# Altium schematic sheet power.SchDoc(power)
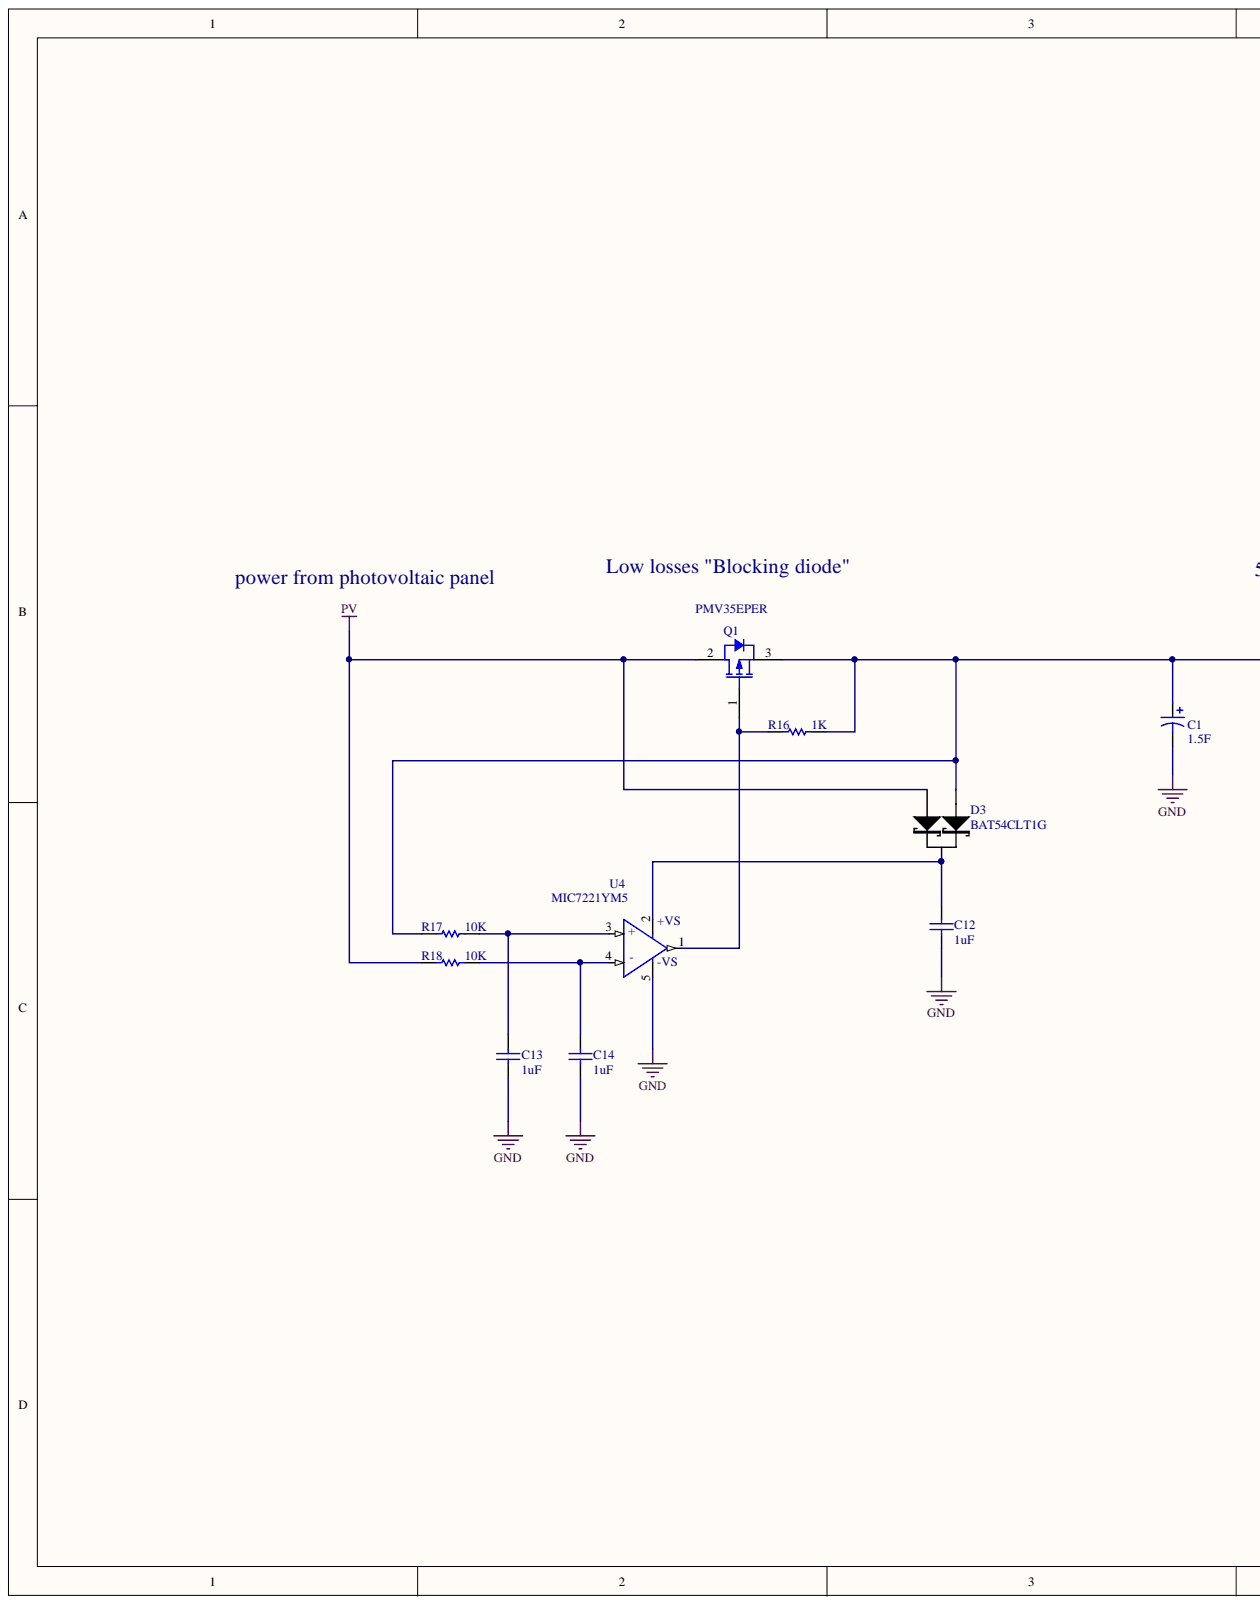
[diagram p0: power.SchDoc, left]
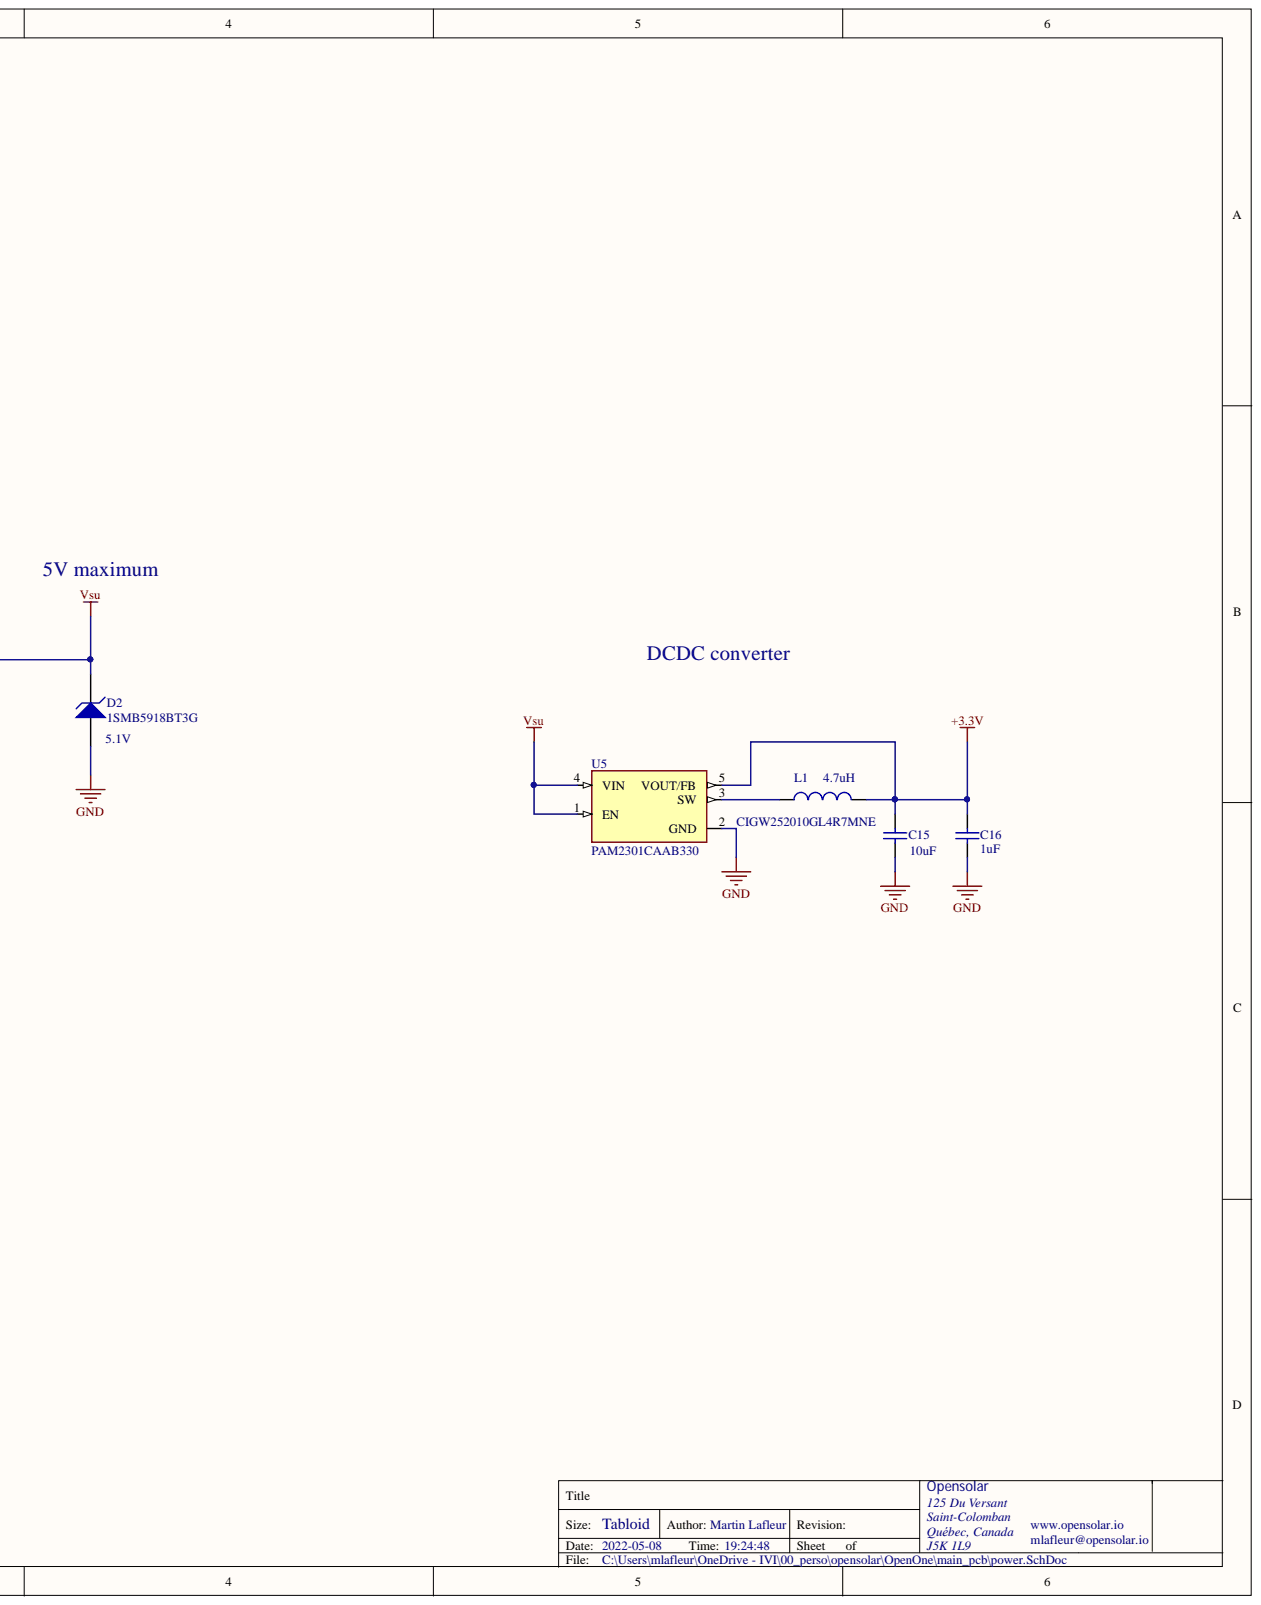
[diagram p1: power.SchDoc, right]

## Parts
C1  value 1.5F  [SCMR22H155PSBB0]  (hidden comment: Cap Pol1)
C12  1uF  [6-0805_M]
C13  1uF  [6-0805_M]
C14  1uF  [6-0805_M]
C15  10uF  [6-0805_M]
C16  1uF  [6-0805_M]
D2  1SMB5918BT3G  value 5.1V  [SMB]
D3  BAT54CLT1G  [SOT23-DUODIODE]
L1  CIGW252010GL4R7MNE  value 4.7uH  [INDUCTOR_2520 - duplicate1]
Q1  PMV35EPER  [PMV35EPER]
R16  1K  [6-0805_N]
R17  10K  [6-0805_N]
R18  10K  [6-0805_N]
U4  MIC7221YM5  [MIC7221YM5]
U5  PAM2301CAAB330  [SOT95P280X100-5N]

## Nets
+3.3V: C15.2 C16.2 L1.2 U5.5(VOUT/FB)
GND: C1.2 C12.1 C13.1 C14.1 C15.1 C16.1 D2.1 U4.5 U5.2(GND)
PV: D3.2 Q1.2 R18.1
Vsu: C1.1 D2.2 D3.1 Q1.3 R16.2 R17.1 U5.1(EN) U5.4(VIN)
NetC12_2: C12.2 D3.3 U4.2
NetC13_2: C13.2 R17.2 U4.3(+)
NetC14_2: C14.2 R18.2 U4.4
NetL1_1: L1.1 U5.3(SW)
NetQ1_1: Q1.1 R16.1 U4.1

## Library data of the parts
C1 = Cap Pol1: Polarized Capacitor (Radial); Published: 8-Jun-2000; PackageReference: RB7.6-15; PackageDescription: Polarized Capacitor (Radial); 2 Leads; Library Name: Miscellaneous Devices.IntLib; LatestRevisionNote: Re-released for DXP Platform.; LatestRevisionDate: 17-Jul-2002; Component Kind: Standard; Publisher: Altium Limited
C12-C14 = Cap: Capacitor; Value: 1uF; Published: 8-Jun-2000; PackageReference: RAD-0.3; PackageDescription: Radial Cap, Thru-Hole; 2 Leads; 0.3 in Pin Spacing; Library Name: Miscellaneous Devices.IntLib; LatestRevisionNote: Re-released for DXP Platform.; LatestRevisionDate: 17-Jul-2002; jlc: C28323; Component Kind: Standard; Publisher: Altium Limited
C15 = Cap: Value: 10uF; Published: 8-Jun-2000; PackageReference: RAD-0.3; PackageDescription: Radial Cap, Thru-Hole; 2 Leads; 0.3 in Pin Spacing; Library Name: Miscellaneous Devices.IntLib; LatestRevisionNote: Re-released for DXP Platform.; LatestRevisionDate: 17-Jul-2002; jlc: C15850; Component Kind: Standard; Publisher: Altium Limited
C16 = Cap: Capacitor; Value: 100nF; Published: 8-Jun-2000; PackageReference: RAD-0.3; PackageDescription: Radial Cap, Thru-Hole; 2 Leads; 0.3 in Pin Spacing; Library Name: Miscellaneous Devices.IntLib; LatestRevisionNote: Re-released for DXP Platform.; LatestRevisionDate: 17-Jul-2002; jlc: C28323; Component Kind: Standard; Publisher: Altium Limited
D2 = D Zener: Zener Diode; Published: 8-Jun-2000; PackageReference: DIODE-0.7; PackageDescription: Diode, Thru-Hole; 2 Leads; 0.7 in Pin Spacing; Library Name: Miscellaneous Devices.IntLib; LatestRevisionNote: Re-released for DXP Platform.; LatestRevisionDate: 17-Jul-2002; jlc: C142361; Component Kind: Standard; Publisher: Altium Limited
D3 = BAT54C-SOT23: DUAL SCHOTTKY DIODE COMMON CATHODE; Manufacturer Part Number: BAT54C,215; Manufacturer: NXP Semiconductors; Voltage - Forward (Vf) (Max) @ If: 800mV @ 100mA; Voltage - DC Reverse (Vr) (Max): 30V; Speed: Small Signal =< 200mA (Io), Any Speed; Reverse Recovery Time (trr): 5ns; Diode Configuration: 1 Pair Common Cathode; Current - Reverse Leakage @ Vr: 2µA @ 25V; Current - Average Rectified (Io) (per Diode): 200mA (DC); Supplier Part Number 1: 568-1612-1-ND; Supplier Part Number: 568-1612-1-ND; Supplier 1: Digi-Key; Supplier: Digi-Key; RoHS: RoHS Compliant; Packaging: Cut Tape (CT); Package / Case: SOT-23-3, TO-236-3, Micro3™, SSD3, SST3; Mounting Type: Surface Mount; Library Name: vh_altium_library.intLib; jlc: C14308; Diode Type: Schottky; Component Kind: Standard; Category: Discrete Semiconductor Products
L1 = Inductor: Code_IEC: 1005; Published: 8-Jun-2000; PackageReference: 0402-A; PackageDescription: Chip Inductor; Library Name: Miscellaneous Devices.IntLib; LatestRevisionNote: IPC-7351 Footprint Added.; LatestRevisionDate: 29-May-2009; jlc: C86070; Description: Inductor; Component Kind: Standard; Code_JEITA: 0402; Publisher: Altium Limited
Q1 = MOSFET-P: P-Channel MOSFET; Published: 8-Jun-2000; PackageVersion: 1995/1996; PackageReference: E3; PackageDescription: TO, Flat Index; 3 In-Line, Axial Leads; Body Dia. 4.6mm; Lead Dia. 0.5 mm (max); LatestRevisionNote: Re-released for DXP Platform.; LatestRevisionDate: 17-Jul-2002; Component Kind: Standard; Publisher: Altium Limited; Library Name: laf.SchLib
R16-R18 = Res1: Resistor; Published: 8-Jun-2000; PackageReference: AXIAL-0.3; PackageDescription: Axial Device, Thru-Hole; 2 Leads; 0.3 in Pin Spacing; Library Name: Miscellaneous Devices.IntLib; LatestRevisionNote: Re-released for DXP Platform.; LatestRevisionDate: 17-Jul-2002; Component Kind: Standard; Publisher: Altium Limited
  R16: Value: 1K; jlc: C17513
  R17 R18: Value: 10K; jlc: C17414
U4 = MIC7221YM5-TR: Comparator General Purpose Open Drain SOT-23-5; MF: Microchip; SnapEDA_Link: https://www.snapeda.com/parts/MIC7221YM5-TR/Microchip/view-part/1222183/?ref=snap; Price: None; Package: SOT-23 Microchip; MP: MIC7221YM5-TR; Component Kind: Standard; Availability: Unavailable; Library Name: laf.SchLib
U5 = PAM2301CAAB120: Buck Switching Regulator IC Positive Fixed 1.2V 1 Output 800mA SOT-23-5 Thin, TSOT-23-5; MF: Diodes Inc.; SnapEDA_Link: https://www.snapeda.com/parts/PAM2301CAAB120/Diodes%20Inc./view-part/924331/?ref=snap; Price: None; Package: SOT-23-5 Diodes Inc.; MP: PAM2301CAAB120; jlc: C9051; Component Kind: Standard; Availability: Unavailable; Library Name: laf.SchLib
